# Altium schematic sheet Page4_GSM.SchDoc
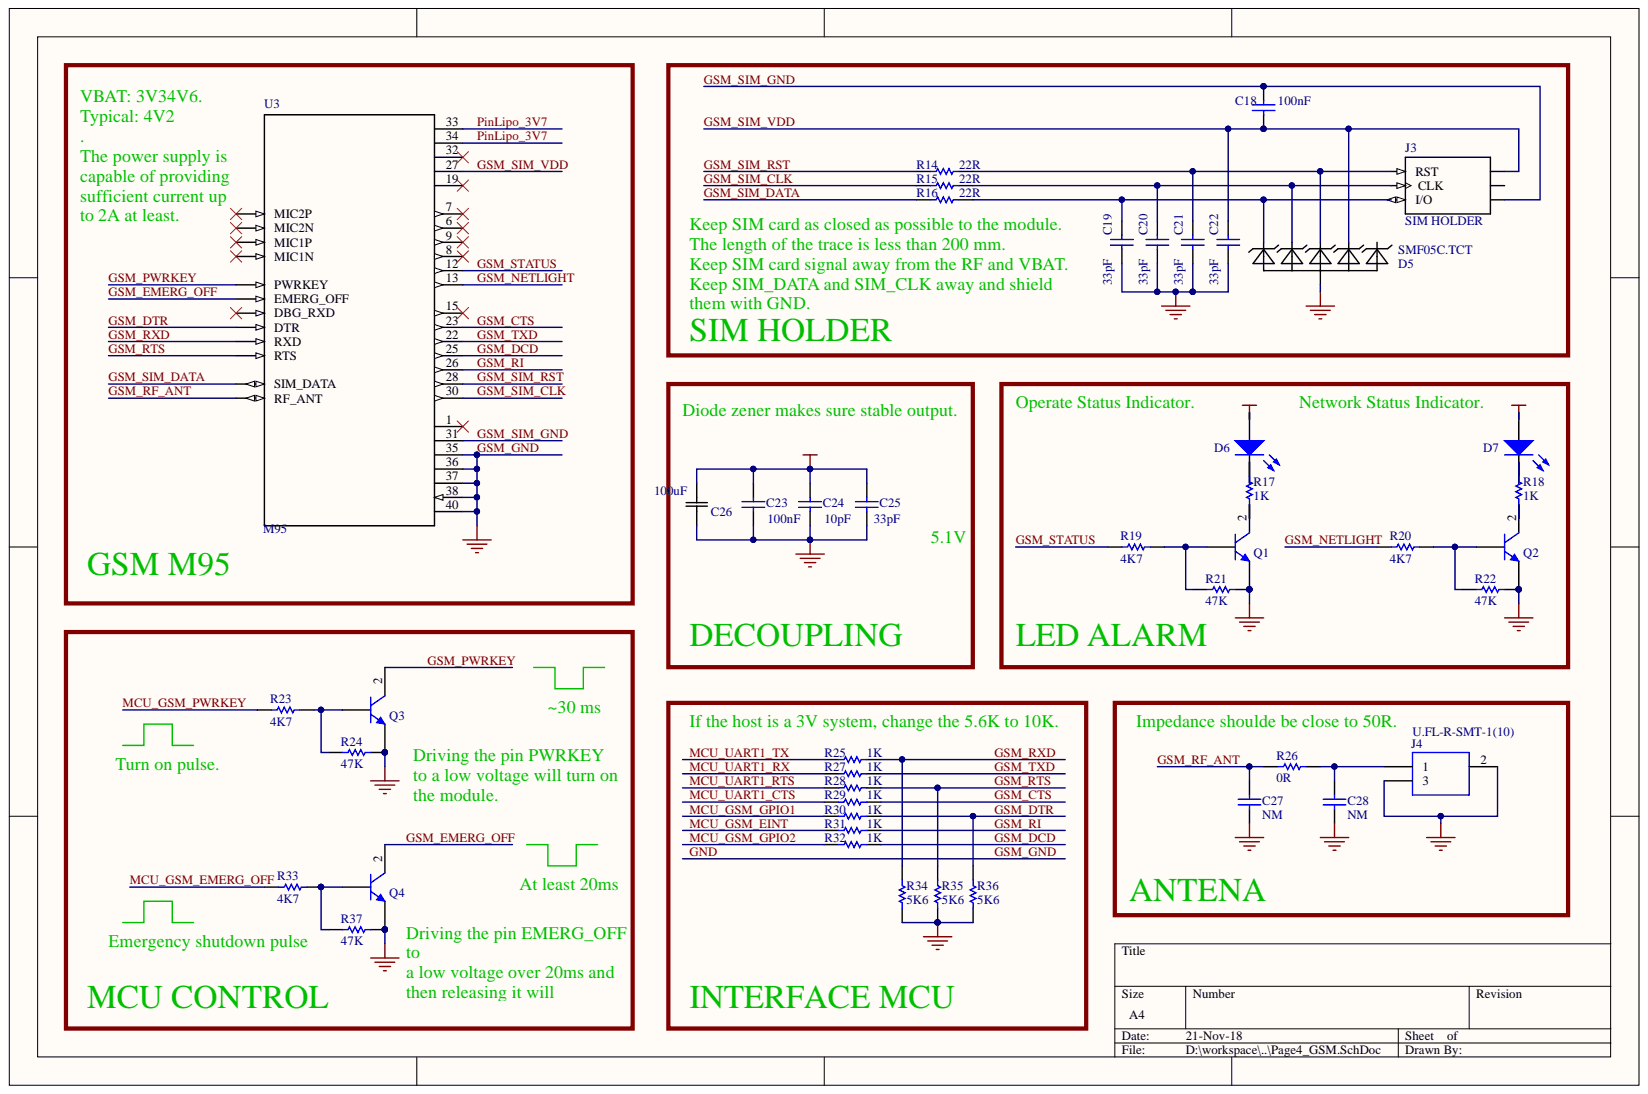
[diagram p0: Page4_GSM.SchDoc]

## Parts
C18  value 100nF  [CAP-SM-0603]  (hidden comment: Cap Non-Pol SMD)
C19  value 33pF  [CAP-SM-0603]  (hidden comment: Cap Non-Pol SMD)
C20  value 33pF  [CAP-SM-0603]  (hidden comment: Cap Non-Pol SMD)
C21  value 33pF  [CAP-SM-0603]  (hidden comment: Cap Non-Pol SMD)
C22  value 33pF  [CAP-SM-0603]  (hidden comment: Cap Non-Pol SMD)
C23  value 100nF  [CAP-SM-0603]  (hidden comment: Cap Non-Pol SMD)
C24  value 10pF  [CAP-SM-0603]  (hidden comment: Cap Non-Pol SMD)
C25  value 33pF  [CAP-SM-0603]  (hidden comment: Cap Non-Pol SMD)
C26  100uF  [CAPCP7343X310N]
C27  value NM  [CAP-SM-0603]  (hidden comment: Cap Non-Pol SMD)
C28  value NM  [CAP-SM-0603]  (hidden comment: Cap Non-Pol SMD)
D5  SMF05C.TCT  [SC70-6]
D6  LED SMD  [LED_0603_Blue]  (value not drawn)
D7  LED SMD  [LED_0603_Blue]  (value not drawn)
J3  SIM HOLDER  [GCT_SIM8050-6-0-14-00-X_REVF_SIM8050-6-0-14-00-X_REVF(Primary)]
J4  U.FL-R-SMT-1(10)  [U.FL-R-SMT-1(10)]
Q1  C1815  [SOT23B]  (value not drawn)
Q2  C1815  [SOT23B]  (value not drawn)
Q3  C1815  [SOT23B]  (value not drawn)
Q4  C1815  [SOT23B]  (value not drawn)
R14  value 22R  [RES-SM-0603]  (hidden comment: RESISTOR SMD)
R15  value 22R  [RES-SM-0603]  (hidden comment: RESISTOR SMD)
R16  value 22R  [RES-SM-0603]  (hidden comment: RESISTOR SMD)
R17  value 1K  [RES-SM-0603]  (hidden comment: RESISTOR SMD)
R18  value 1K  [RES-SM-0603]  (hidden comment: RESISTOR SMD)
R19  value 4K7  [RES-SM-0603]  (hidden comment: RESISTOR SMD)
R20  value 4K7  [RES-SM-0603]  (hidden comment: RESISTOR SMD)
R21  value 47K  [RES-SM-0603]  (hidden comment: RESISTOR SMD)
R22  value 47K  [RES-SM-0603]  (hidden comment: RESISTOR SMD)
R23  value 4K7  [RES-SM-0603]  (hidden comment: RESISTOR SMD)
R24  value 47K  [RES-SM-0603]  (hidden comment: RESISTOR SMD)
R25  value 1K  [RES-SM-0603]  (hidden comment: RESISTOR SMD)
R26  value 0R  [RES-SM-0603]  (hidden comment: RESISTOR SMD)
R27  value 1K  [RES-SM-0603]  (hidden comment: RESISTOR SMD)
R28  value 1K  [RES-SM-0603]  (hidden comment: RESISTOR SMD)
R29  value 1K  [RES-SM-0603]  (hidden comment: RESISTOR SMD)
R30  value 1K  [RES-SM-0603]  (hidden comment: RESISTOR SMD)
R31  value 1K  [RES-SM-0603]  (hidden comment: RESISTOR SMD)
R32  value 1K  [RES-SM-0603]  (hidden comment: RESISTOR SMD)
R33  value 4K7  [RES-SM-0603]  (hidden comment: RESISTOR SMD)
R34  value 5K6  [RES-SM-0603]  (hidden comment: RESISTOR SMD)
R35  value 5K6  [RES-SM-0603]  (hidden comment: RESISTOR SMD)
R36  value 5K6  [RES-SM-0603]  (hidden comment: RESISTOR SMD)
R37  value 47K  [RES-SM-0603]  (hidden comment: RESISTOR SMD)
U3  M95  [XCVR_M95]

## Nets
3V3: D6.1 D7.1
GND: C19.1 C20.1 C21.1 C22.1 C23.1 C24.1 C25.1 C26.2 C27.1 C28.1 D5.2 J4.2 J4.3 Q1.1 Q2.1 Q3.1 Q4.1 R21.2 R22.2 R24.2 R34.1 R35.1 R36.1 R37.2 U3.35 U3.36 U3.37 U3.38 U3.40
GSM_CTS: R29.2 U3.23
GSM_DCD: R32.2 U3.25
GSM_DTR: R30.2 R36.2 U3.20(DTR)
GSM_EMERG_OFF: Q4.2 U3.11(EMERG_OFF)
GSM_NETLIGHT: R20.1 U3.13
GSM_PWRKEY: Q3.2 U3.10(PWRKEY)
GSM_RF_ANT: C27.2 R26.1 U3.39(RF_ANT)
GSM_RI: R31.2 U3.26
GSM_RTS: R28.2 R35.2 U3.24(RTS)
GSM_RXD: R25.2 R34.2 U3.21(RXD)
GSM_SIM_CLK: R15.1 U3.30
GSM_SIM_DATA: R16.1 U3.29(SIM_DATA)
GSM_SIM_GND: C18.2 J3.C5 U3.31
GSM_SIM_RST: R14.1 U3.28
GSM_SIM_VDD: C18.1 C22.2 D5.5 J3.C1 U3.27
GSM_STATUS: R19.1 U3.12
GSM_TXD: R27.2 U3.22
MCU_GSM_EINT: R31.1
MCU_GSM_EMERG_OFF: R33.1
MCU_GSM_GPIO1: R30.1
MCU_GSM_GPIO2: R32.1
MCU_GSM_PWRKEY: R23.1
MCU_UART1_CTS: R29.1
MCU_UART1_RTS: R28.1
MCU_UART1_RX: R27.1
MCU_UART1_TX: R25.1
PinLipo_3V7: C23.2 C24.2 C25.2 C26.1 U3.33 U3.34
NetC19_2: C19.2 D5.1 J3.C7(I/O) R16.2
NetC20_2: C20.2 D5.3 J3.C3(CLK) R15.2
NetC21_2: C21.2 D5.4 J3.C2(RST) R14.2
NetC28_2: C28.2 J4.1 R26.2
NetD6_2: D6.2 R17.2
NetD7_2: D7.2 R18.2
NetQ1_2: Q1.2 R17.1
NetQ1_3: Q1.3 R19.2 R21.1
NetQ2_2: Q2.2 R18.1
NetQ2_3: Q2.3 R20.2 R22.1
NetQ3_3: Q3.3 R23.2 R24.1
NetQ4_3: Q4.3 R33.2 R37.1

Not connected: D5 6; J3 C6; U3 1 2(MIC2P) 3(MIC2N) 4(MIC1P) 5(MIC1N) 6 7 8 9 14(DBG_RXD) 15 19 32

## Library data of the parts
C18-C25 C27 C28 = Cap Non-Pol SMD: Capacitor; Component Kind: Standard; Library Name: PIF_Library.IntLib
C26 = TAJD107M010R: Cap Tant Solid 100uF 10V D CASE 20% _7.3 X 4.3 X 2.9mm_ Inward L SMD 7343-31 0.9 Ohm 125C T/R; MF: AVX; Availability: Unavailable; Component Kind: Standard; Library Name: TAJD107M010R.SchLib; MP: TAJD107M010R; Package: None; Price: None; SnapEDA_Link: https://www.snapeda.com/parts/TAJD107M010R/AVX/view-part/?ref=snap
D5 = SMF05C.TCT: MF: Semtech; Availability: Unavailable; Component Kind: Standard; Library Name: SMF05C.TCT.SchLib; MP: SMF05C.TCT; Package: SOT-363 Diodes Inc.; Price: None; SnapEDA_Link: https://www.snapeda.com/parts/SMF05C.TCT/Semtech%20Corporation/view-part/?ref=snap
D6 D7 = LED SMD: LED; Component Kind: Standard; Library Name: PIF_Library.IntLib
J3 = SIM8050-6-0-14-00-X_REVF: Nano SIM Retainer, 6P, SMT, 1.35mm Profile, No Peg, Without switch, T&R; MF: Global Connector Technology; MP: SIM8050-6-0-14-00-A; Availability: Unavailable; Component Kind: Standard; Library Name: SIM8050-6-0-14-00-X_REVF.SchLib; Package: None; Price: None; SnapEDA_Link: https://www.snapeda.com/parts/SIM8050-6-0-14-00-A/Global%20Connector%20Technology/view-part/?ref=snap
J4 = U.FL-R-SMT-1(10): UFL SMT PCB receptable,DC-6GHz Hirose Straight 50 Surface Mount UFL Connector, Receptacle, Solder Termination Coaxial; Arrow Part Number: U.FL-R-SMT-1(10); Arrow Price/Stock: https://www.arrow.com/en/products/u.fl-r-smt-1-10/hirose-electric; Component Kind: Standard; Datasheet Link: https://www.hirose.com/product/en/download_file/key_name/U.FL-R-SMT-1%2810%29/category/Drawing%20(2D)/doc_file_id/37627/?file_category_id=6&item_id=03310472210&is_series=; Library Name: U.FL-R-SMT-1(10).SchLib; Manufacturer_Name: Hirose; Manufacturer_Part_Number: U.FL-R-SMT-1(10); RS Part Number: 1757510; RS Price/Stock: http://uk.rs-online.com/web/p/products/1757510
Q1-Q4 = C1815: NPN Bipolar Transistor; Component Kind: Standard; Library Name: PIF_Library.IntLib
R14-R37 = RESISTOR SMD: Resistor; Component Kind: Standard; Library Name: PIF_Library.IntLib
U3 = M95: Quad-Band Gsm/Gprs Module; MF: Quectel; Availability: Unavailable; Component Kind: Standard; Library Name: M95.SchLib; MP: M95; Package: SMD-16 Quectel; Price: None; SnapEDA_Link: https://www.snapeda.com/parts/M95/Quectel/view-part/?ref=snap
